# Altium schematic sheet TSAL_Schematic.SchDoc
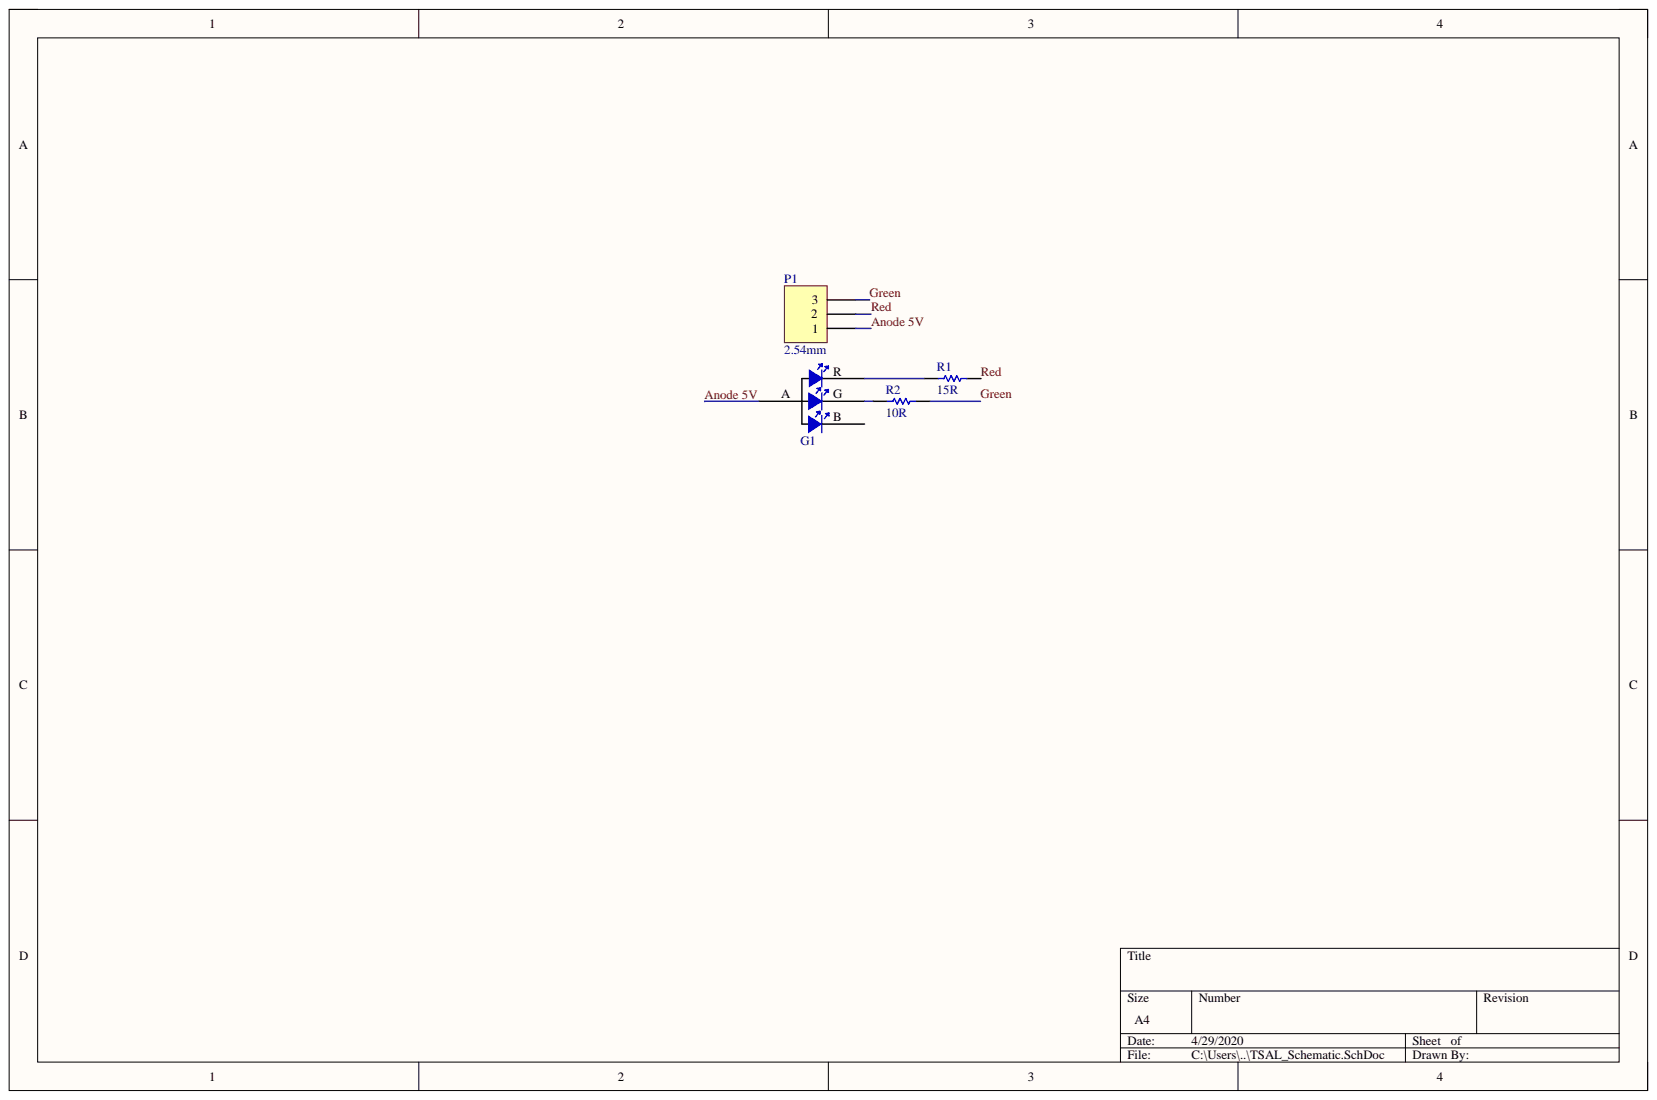
[diagram p0: TSAL_Schematic.SchDoc]

## Parts
G1  G  [HOTRED RGB_LED]
P1  2.54mm  [TH 2.54mm Header 1x3]
R1  15R  [2512]
R2  10R  [2512]

## Nets
Anode 5V: G1.A P1.1
Green: P1.3 R2.2
Red: P1.2 R1.2
NetG1_G: G1.G R2.1
NetG1_R: G1.R R1.1

Not connected: G1 B

## Library data of the parts
G1 = LED - RGB (Anode Common): Component Kind: Standard; Library Name: analogue.SchLib
P1 = TH 2.54mm Header 1x3: Angle: 0; Contact: NA; Database Table Name: Generic$; Flange: No; Positions: 3; Component Kind: Standard; Library Name: ts_20-standard-connectors.DbLib; Part Number: TH 2.54mm Header 1x3; Pitch: 2.54mm; Row Spacing: 2.54mm
R1 = 352115RFT: Manufacturer: TE Connectivity; Database Table Name: Resistors$; Rated Voltage: 2W; Tolerance: 0.01; Component Kind: Standard; Digikey Part Number: A115988CT-ND; Library Name: ts_20-standard-library.DbLib; Part Number: 352115RFT; Value: 15R
R2 = 352110RFT: Manufacturer: TE Connectivity; Database Table Name: Resistors$; Rated Voltage: 2W; Tolerance: 0.01; Component Kind: Standard; Digikey Part Number: A115984CT-ND; Library Name: ts_20-standard-library.DbLib; Part Number: 352110RFT; Value: 10R
